# Altium schematic sheet OUTPUT_8_RELAY.SchDoc(OUTPUT_8_RELAY)
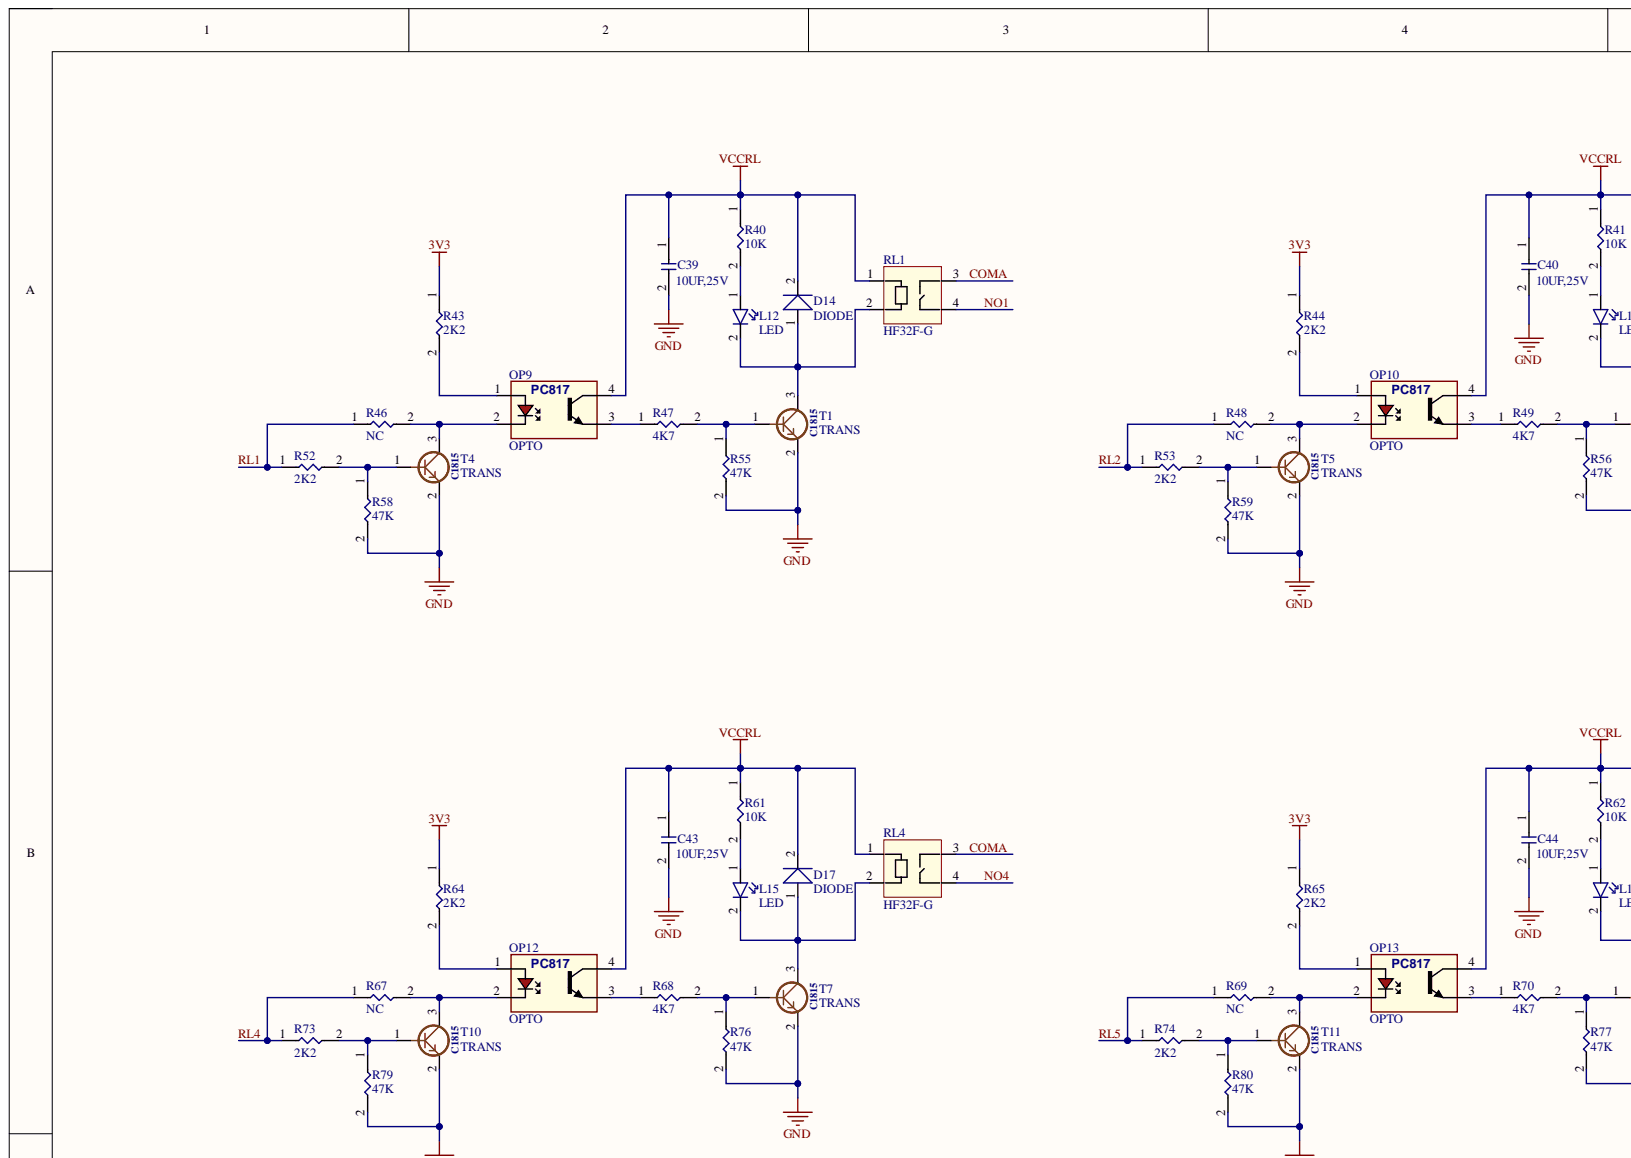
[diagram p0: OUTPUT_8_RELAY.SchDoc, top left]
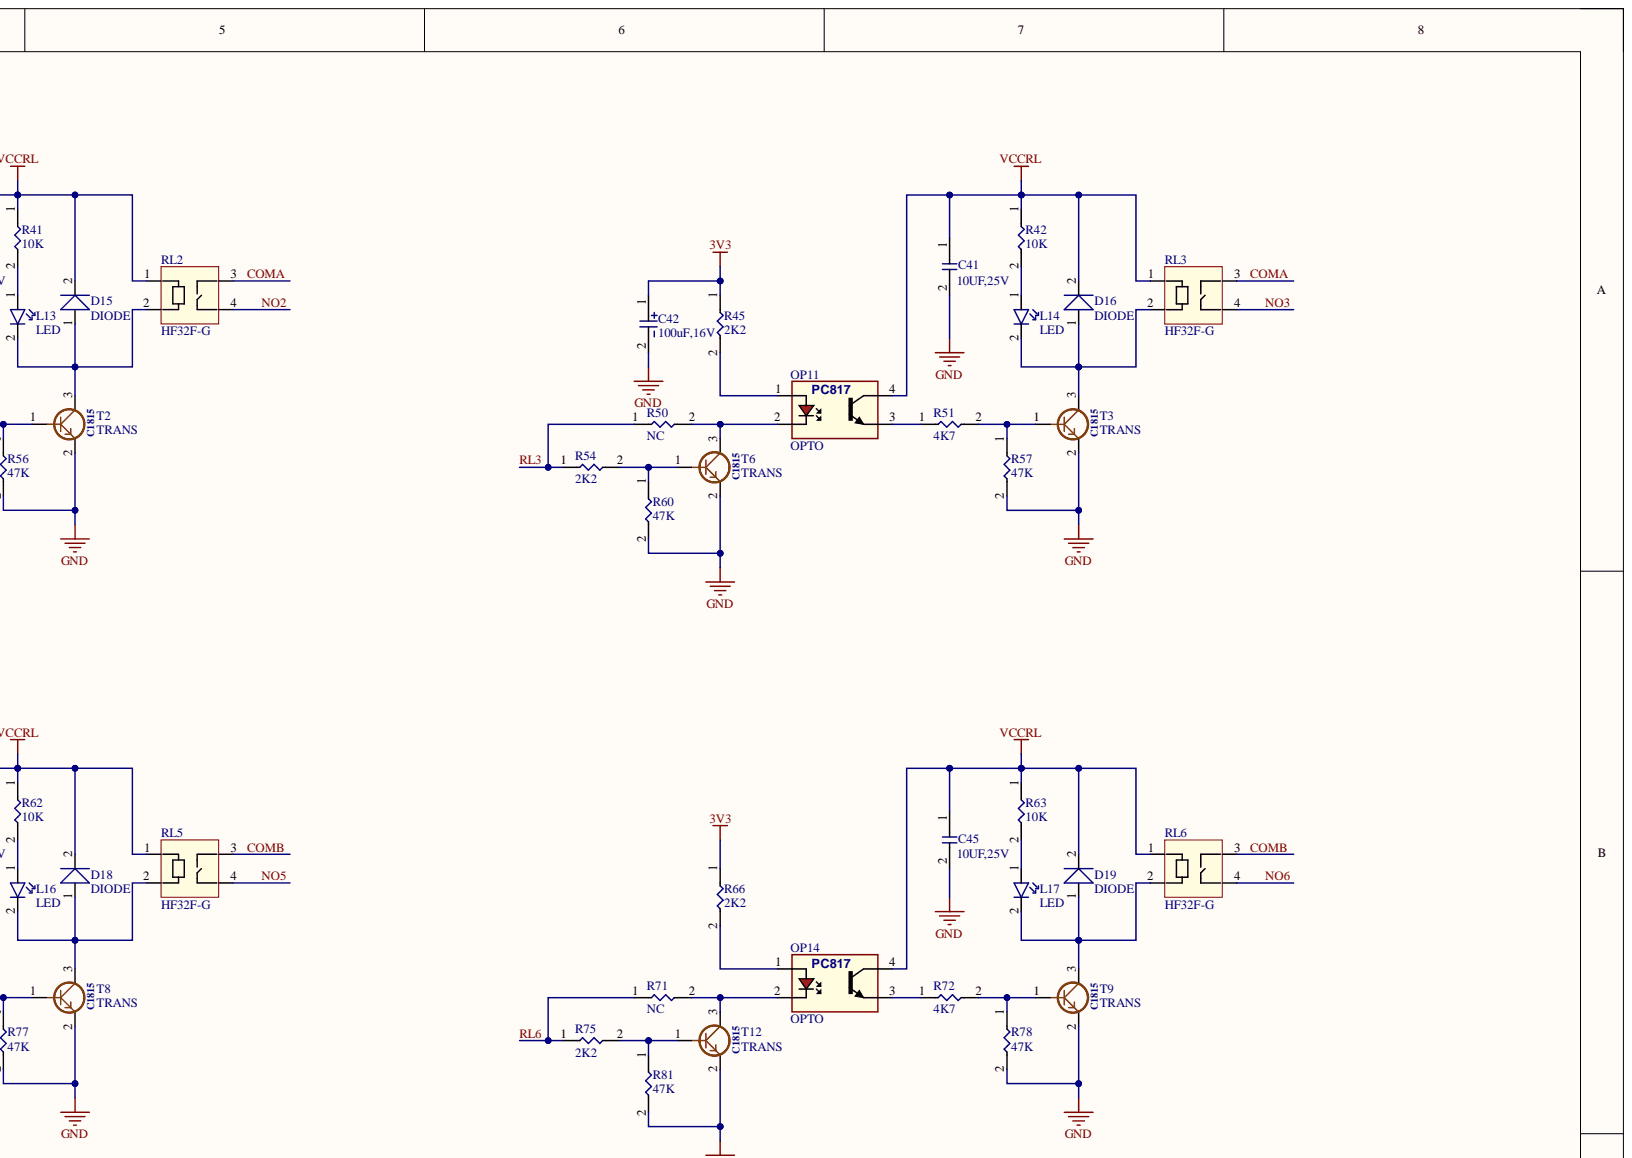
[diagram p1: OUTPUT_8_RELAY.SchDoc, top right]
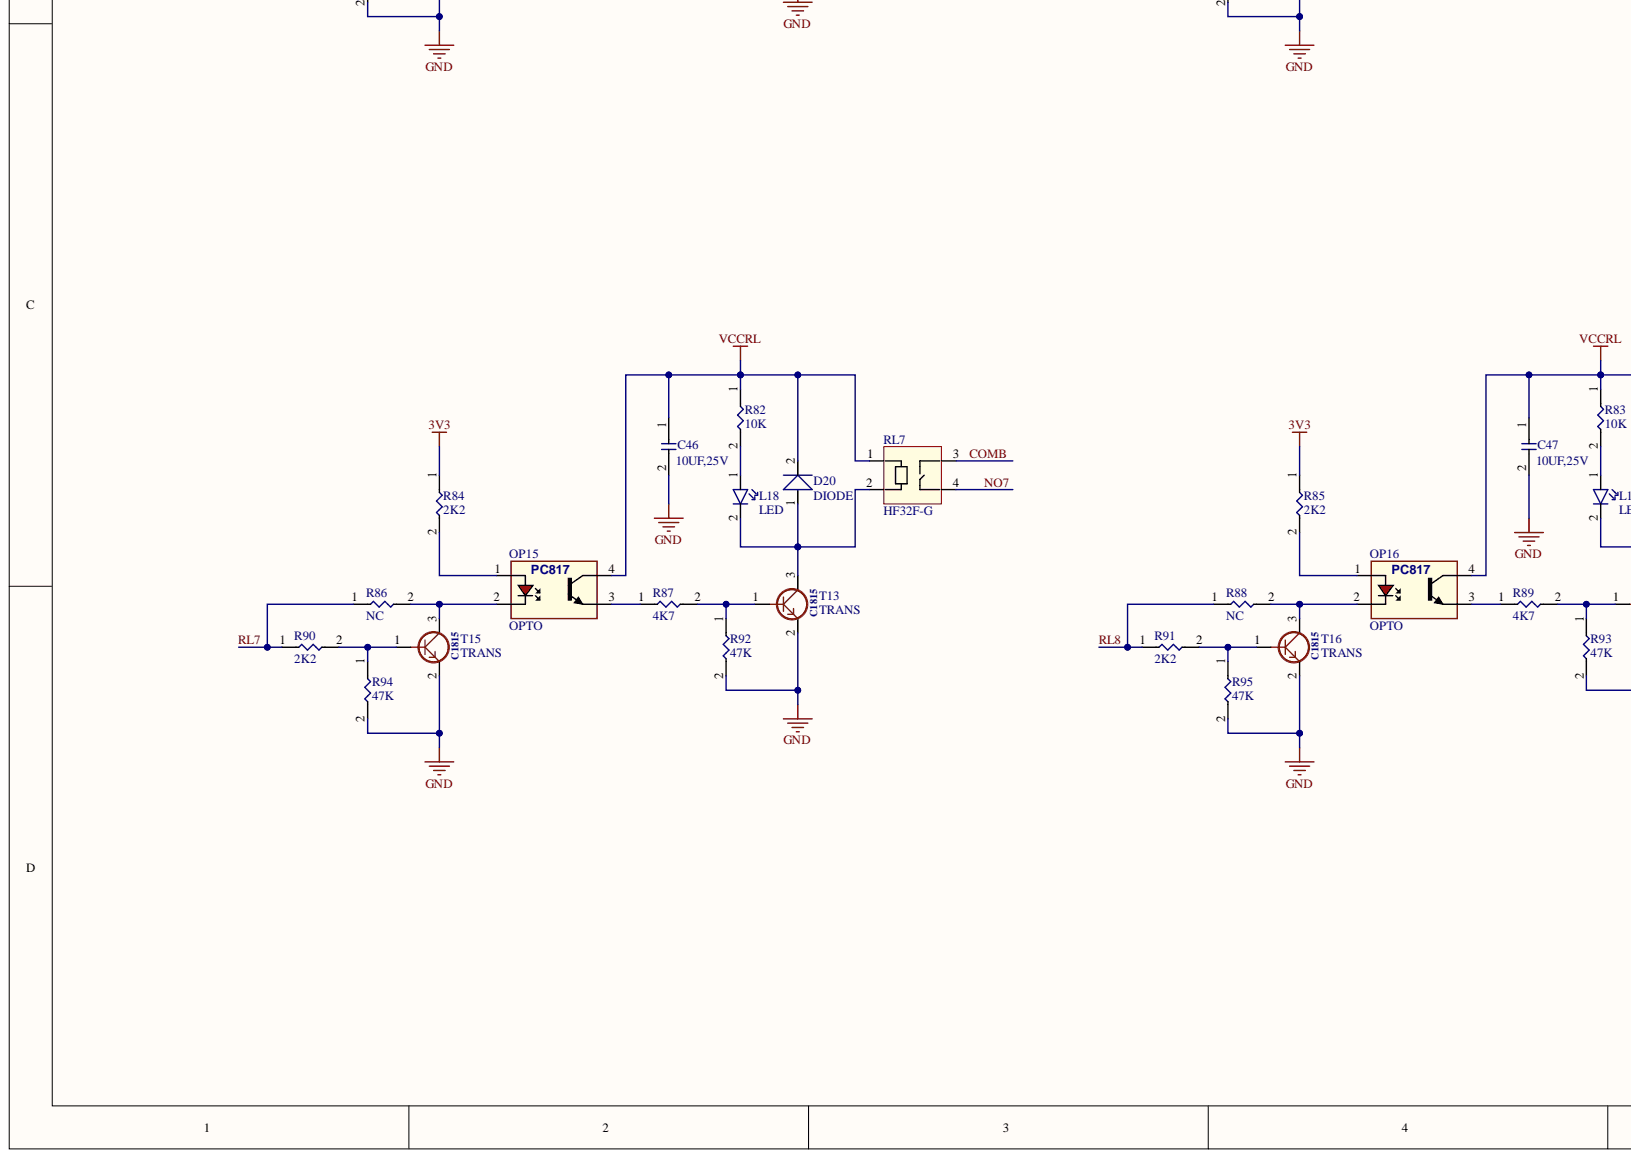
[diagram p2: OUTPUT_8_RELAY.SchDoc, bottom left]
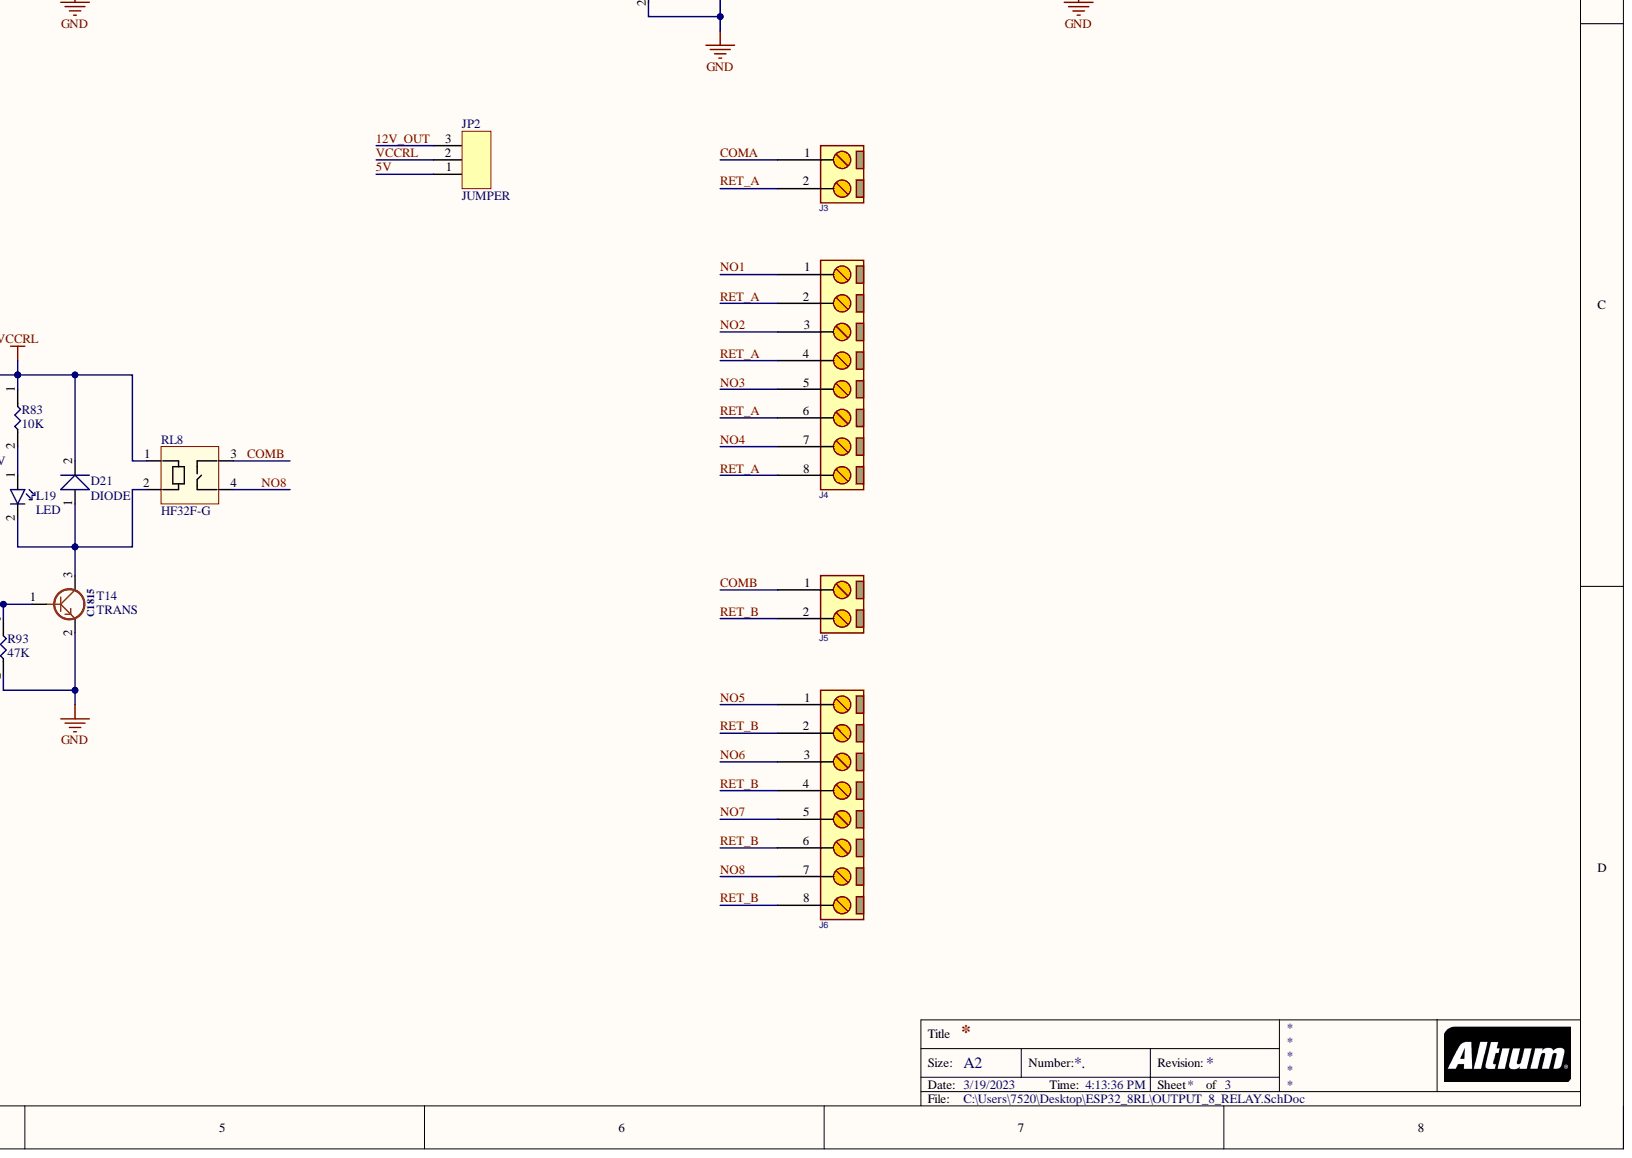
[diagram p3: OUTPUT_8_RELAY.SchDoc, bottom right]

## Parts
C39  10UF,25V  [cap_smd_0805]
C40  10UF,25V  [cap_smd_0805]
C41  10UF,25V  [cap_smd_0805]
C42  100uF,16V  [CAP_TAN_B]
C43  10UF,25V  [cap_smd_0805]
C44  10UF,25V  [cap_smd_0805]
C45  10UF,25V  [cap_smd_0805]
C46  10UF,25V  [cap_smd_0805]
C47  10UF,25V  [cap_smd_0805]
D14  DIODE  [DIODE_SMD_SOD_123]
D15  DIODE  [DIODE_SMD_SOD_123]
D16  DIODE  [DIODE_SMD_SOD_123]
D17  DIODE  [DIODE_SMD_SOD_123]
D18  DIODE  [DIODE_SMD_SOD_123]
D19  DIODE  [DIODE_SMD_SOD_123]
D20  DIODE  [DIODE_SMD_SOD_123]
D21  DIODE  [DIODE_SMD_SOD_123]
J3  CONN_TB2  [P_MSTBVF_2]  (value not drawn)
J4  CONN_TB8  [P_TBG_8]  (value not drawn)
J5  CONN_TB2  [P_MSTBVF_2]  (value not drawn)
J6  CONN_TB8  [P_TBG_8]  (value not drawn)
JP2  JUMPER  [JP3_PWR]
L12  LED  [LED_0603_GREEN]
L13  LED  [LED_0603_GREEN]
L14  LED  [LED_0603_GREEN]
L15  LED  [LED_0603_GREEN]
L16  LED  [LED_0603_GREEN]
L17  LED  [LED_0603_GREEN]
L18  LED  [LED_0603_GREEN]
L19  LED  [LED_0603_GREEN]
OP9  OPTO  [PC817_SMD]
OP10  OPTO  [PC817_SMD]
OP11  OPTO  [PC817_SMD]
OP12  OPTO  [PC817_SMD]
OP13  OPTO  [PC817_SMD]
OP14  OPTO  [PC817_SMD]
OP15  OPTO  [PC817_SMD]
OP16  OPTO  [PC817_SMD]
R40  10K  [0603_R_L]
R41  10K  [0603_R_L]
R42  10K  [0603_R_L]
R43  2K2  [0603_R_L]
R44  2K2  [0603_R_L]
R45  2K2  [0603_R_L]
R46  NC  [0603_R_L]
R47  4K7  [0603_R_L]
R48  NC  [0603_R_L]
R49  4K7  [0603_R_L]
R50  NC  [0603_R_L]
R51  4K7  [0603_R_L]
R52  2K2  [0603_R_L]
R53  2K2  [0603_R_L]
R54  2K2  [0603_R_L]
R55  47K  [0603_R_L]
R56  47K  [0603_R_L]
R57  47K  [0603_R_L]
R58  47K  [0603_R_L]
R59  47K  [0603_R_L]
R60  47K  [0603_R_L]
R61  10K  [0603_R_L]
R62  10K  [0603_R_L]
R63  10K  [0603_R_L]
R64  2K2  [0603_R_L]
R65  2K2  [0603_R_L]
R66  2K2  [0603_R_L]
R67  NC  [0603_R_L]
R68  4K7  [0603_R_L]
R69  NC  [0603_R_L]
R70  4K7  [0603_R_L]
R71  NC  [0603_R_L]
R72  4K7  [0603_R_L]
R73  2K2  [0603_R_L]
R74  2K2  [0603_R_L]
R75  2K2  [0603_R_L]
R76  47K  [0603_R_L]
R77  47K  [0603_R_L]
R78  47K  [0603_R_L]
R79  47K  [0603_R_L]
R80  47K  [0603_R_L]
R81  47K  [0603_R_L]
R82  10K  [0603_R_L]
R83  10K  [0603_R_L]
R84  2K2  [0603_R_L]
R85  2K2  [0603_R_L]
R86  NC  [0603_R_L]
R87  4K7  [0603_R_L]
R88  NC  [0603_R_L]
R89  4K7  [0603_R_L]
R90  2K2  [0603_R_L]
R91  2K2  [0603_R_L]
R92  47K  [0603_R_L]
R93  47K  [0603_R_L]
R94  47K  [0603_R_L]
R95  47K  [0603_R_L]
RL1  HF32F-G  [HF32F-G-JZC-32F-G]
RL2  HF32F-G  [HF32F-G-JZC-32F-G]
RL3  HF32F-G  [HF32F-G-JZC-32F-G]
RL4  HF32F-G  [HF32F-G-JZC-32F-G]
RL5  HF32F-G  [HF32F-G-JZC-32F-G]
RL6  HF32F-G  [HF32F-G-JZC-32F-G]
RL7  HF32F-G  [HF32F-G-JZC-32F-G]
RL8  HF32F-G  [HF32F-G-JZC-32F-G]
T1  TRANS  [C1815]
T2  TRANS  [C1815]
T3  TRANS  [C1815]
T4  TRANS  [C1815]
T5  TRANS  [C1815]
T6  TRANS  [C1815]
T7  TRANS  [C1815]
T8  TRANS  [C1815]
T9  TRANS  [C1815]
T10  TRANS  [C1815]
T11  TRANS  [C1815]
T12  TRANS  [C1815]
T13  TRANS  [C1815]
T14  TRANS  [C1815]
T15  TRANS  [C1815]
T16  TRANS  [C1815]

## Nets
3V3: C42.1 R43.1 R44.1 R45.1 R64.1 R65.1 R66.1 R84.1 R85.1
GND: C39.2 C40.2 C41.2 C42.2 C43.2 C44.2 C45.2 C46.2 C47.2 R55.2 R56.2 R57.2 R58.2 R59.2 R60.2 R76.2 R77.2 R78.2 R79.2 R80.2 R81.2 R92.2 R93.2 R94.2 R95.2 T1.2 T2.2 T3.2 T4.2 T5.2 T6.2 T7.2 T8.2 T9.2 T10.2 T11.2 T12.2 T13.2 T14.2 T15.2 T16.2
5V: JP2.1
12V_OUT: JP2.3
COMA: J3.1 RL1.3 RL2.3 RL3.3 RL4.3
COMB: J5.1 RL5.3 RL6.3 RL7.3 RL8.3
NO1: J4.1 RL1.4
NO2: J4.3 RL2.4
NO3: J4.5 RL3.4
NO4: J4.7 RL4.4
NO5: J6.1 RL5.4
NO6: J6.3 RL6.4
NO7: J6.5 RL7.4
NO8: J6.7 RL8.4
RET_A: J3.2 J4.2 J4.4 J4.6 J4.8
RET_B: J5.2 J6.2 J6.4 J6.6 J6.8
RL1: R46.1 R52.1
RL2: R48.1 R53.1
RL3: R50.1 R54.1
RL4: R67.1 R73.1
RL5: R69.1 R74.1
RL6: R71.1 R75.1
RL7: R86.1 R90.1
RL8: R88.1 R91.1
VCCRL: C39.1 C40.1 C41.1 C43.1 C44.1 C45.1 C46.1 C47.1 D14.2 D15.2 D16.2 D17.2 D18.2 D19.2 D20.2 D21.2 JP2.2 OP9.4 OP10.4 OP11.4 OP12.4 OP13.4 OP14.4 OP15.4 OP16.4 R40.1 R41.1 R42.1 R61.1 R62.1 R63.1 R82.1 R83.1 RL1.1 RL2.1 RL3.1 RL4.1 RL5.1 RL6.1 RL7.1 RL8.1
NetD14_1: D14.1 L12.2 RL1.2 T1.3
NetD15_1: D15.1 L13.2 RL2.2 T2.3
NetD16_1: D16.1 L14.2 RL3.2 T3.3
NetD17_1: D17.1 L15.2 RL4.2 T7.3
NetD18_1: D18.1 L16.2 RL5.2 T8.3
NetD19_1: D19.1 L17.2 RL6.2 T9.3
NetD20_1: D20.1 L18.2 RL7.2 T13.3
NetD21_1: D21.1 L19.2 RL8.2 T14.3
NetL12_1: L12.1 R40.2
NetL13_1: L13.1 R41.2
NetL14_1: L14.1 R42.2
NetL15_1: L15.1 R61.2
NetL16_1: L16.1 R62.2
NetL17_1: L17.1 R63.2
NetL18_1: L18.1 R82.2
NetL19_1: L19.1 R83.2
NetOP9_1: OP9.1(PC817) R43.2
NetOP9_2: OP9.2 R46.2 T4.3
NetOP9_3: OP9.3 R47.1
NetOP10_1: OP10.1(PC817) R44.2
NetOP10_2: OP10.2 R48.2 T5.3
NetOP10_3: OP10.3 R49.1
NetOP11_1: OP11.1(PC817) R45.2
NetOP11_2: OP11.2 R50.2 T6.3
NetOP11_3: OP11.3 R51.1
NetOP12_1: OP12.1 R64.2
NetOP12_2: OP12.2 R67.2 T10.3
NetOP12_3: OP12.3 R68.1
NetOP13_1: OP13.1 R65.2
NetOP13_2: OP13.2 R69.2 T11.3
NetOP13_3: OP13.3 R70.1
NetOP14_1: OP14.1 R66.2
NetOP14_2: OP14.2 R71.2 T12.3
NetOP14_3: OP14.3 R72.1
NetOP15_1: OP15.1 R84.2
NetOP15_2: OP15.2 R86.2 T15.3
NetOP15_3: OP15.3 R87.1
NetOP16_1: OP16.1 R85.2
NetOP16_2: OP16.2 R88.2 T16.3
NetOP16_3: OP16.3 R89.1
NetR47_2: R47.2 R55.1 T1.1
NetR49_2: R49.2 R56.1 T2.1
NetR51_2: R51.2 R57.1 T3.1
NetR52_2: R52.2 R58.1 T4.1
NetR53_2: R53.2 R59.1 T5.1
NetR54_2: R54.2 R60.1 T6.1
NetR68_2: R68.2 R76.1 T7.1
NetR70_2: R70.2 R77.1 T8.1
NetR72_2: R72.2 R78.1 T9.1
NetR73_2: R73.2 R79.1 T10.1
NetR74_2: R74.2 R80.1 T11.1
NetR75_2: R75.2 R81.1 T12.1
NetR87_2: R87.2 R92.1 T13.1
NetR89_2: R89.2 R93.1 T14.1
NetR90_2: R90.2 R94.1 T15.1
NetR91_2: R91.2 R95.1 T16.1

## Library data of the parts
C39-C41 C43-C47 = CAPACITOR: Component Kind: Standard; Library Name: COMPONENT.IntLib
C42 = CAP_TAN: Component Kind: Standard; Library Name: COMPONENT.IntLib
J3 J5 = Phoenix_2: CONN, PHOENIX MSTB, 5-G, 5.08mm pitch; Component Kind: Standard; Library Name: TERMINAL.IntLib; VALUE: CONN_TB2
J4 J6 = TB8 GREEN: CONN, TERMINAL BLOCK, 5.08mm pitch; Component Kind: Standard; Library Name: TERMINAL.IntLib; VALUE: CONN_TB8
JP2 = JP3: Component Kind: Standard; Library Name: COMPONENT.IntLib
OP9-OP16 = PC817: Component Kind: Standard; Library Name: COMPONENT.IntLib
R40-R95 = RESISTOR: Component Kind: Standard; Description: resistor; Library Name: COMPONENT.IntLib
T1-T16 = C1815: Component Kind: Standard; Library Name: COMPONENT.IntLib
D14-D21 = DIODE: Component Kind: Standard; Library Name: COMPONENT.IntLib
L12-L19 = LED: Component Kind: Standard; Library Name: COMPONENT.IntLib
RL1-RL8 = HF32F-G: Component Kind: Standard; Library Name: COMPONENT.IntLib
